# Altium schematic sheet Sheet1.SchDoc
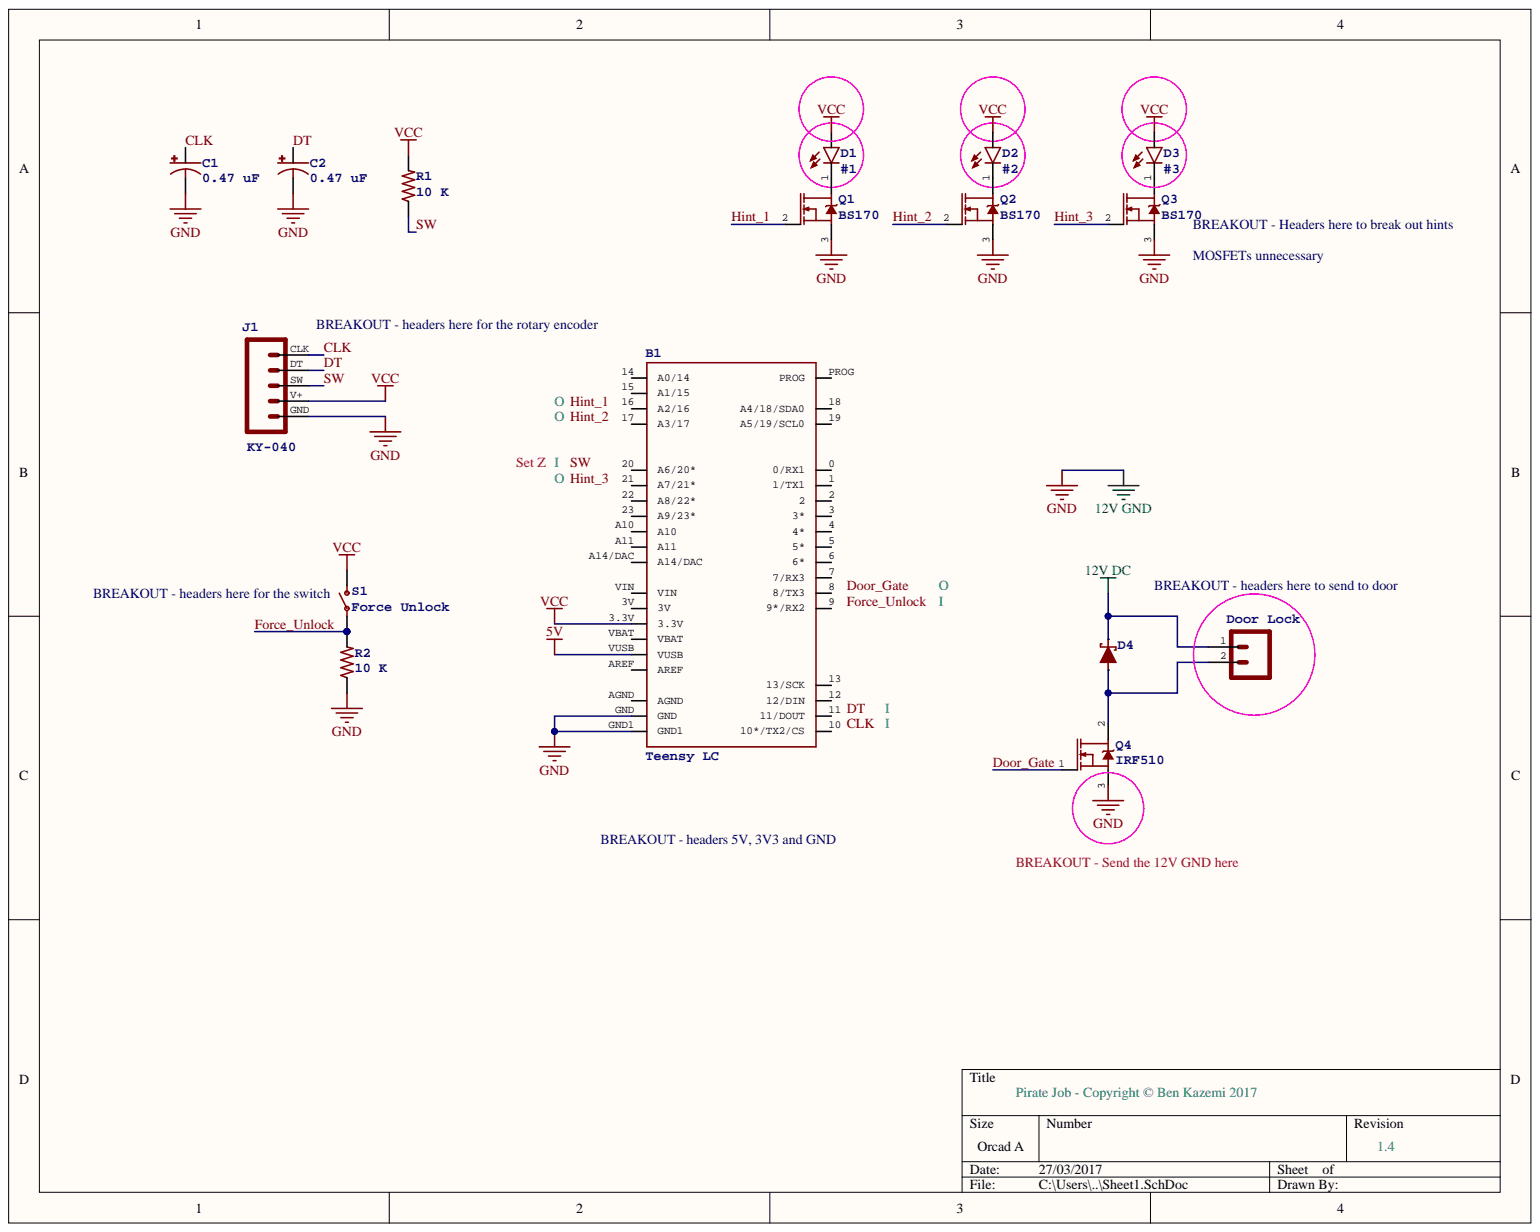
[diagram p0: Sheet1.SchDoc]

## Parts
B1  Teensy LC  [TEENSY-3.1]
C1  0.47 uF  [PANASONIC_D]
C2  0.47 uF  [PANASONIC_D]
D1  #1  [LED_5MM]
D2  #2  [LED_5MM]
D3  #3  [LED_5MM]
D4  (no value)  [SOD-323]
Door_Lock  (no value)  [1X02_LOCK]
J1  KY-040  [1X05]
Q1  BS170  [TO-92]
Q2  BS170  [TO-92]
Q3  BS170  [TO-92]
Q4  IRF510  [TO220V]
R1  10 K  [AXIAL-0.3]
R2  10 K  [AXIAL-0.3]
S1  Force Unlock  [SWITCH-SPST-VERTICAL]

## Nets
5V: B1.VUSB
12V DC: D4.C Door_Lock.1
12V GND: B1.GND B1.GND1 C1.- C2.- J1.GND Q1.3 Q2.3 Q3.3 Q4.3 R2.P$1
VCC: B1.3.3V D1.A D2.A D3.A J1.V+ R1.P$2 S1.1
CLK: C1.+ J1.CLK
DOOR_GATE: Q4.1
DT: C2.+ J1.DT
FORCE_UNLOCK: R2.P$2 S1.2
HINT_1: Q1.2
HINT_2: Q2.2
HINT_3: Q3.2
SW: J1.SW R1.P$1
NetD1_K: D1.K Q1.1
NetD2_K: D2.K Q2.1
NetD3_K: D3.K Q3.1
NetD4_A: D4.A Door_Lock.2 Q4.2

Not connected: B1 0(0/RX1) 1(1/TX1) 2 3(3*) 3V 4(4*) 5(5*) 6(6*) 7(7/RX3) 8(8/TX3) 9(9*/RX2) 10(10*/TX2/CS) 11(11/DOUT) 12(12/DIN) 13(13/SCK) 14(A0/14) 15(A1/15) 16(A2/16) 17(A3/17) 18(A4/18/SDA0) 19(A5/19/SCL0) 20(A6/20*) 21(A7/21*) 22(A8/22*) 23(A9/23*) A10 A11 A14/DAC AGND AREF PROG VBAT VIN

## Library data of the parts
B1 = TEENSY-3.1SILK: SF_ID: DEV-12646; Component Kind: Standard; Library Name: SparkFun-Boards.IntLib
C1 C2 = 47UF-POLAR-PANASONIC_D-50V-20%: Component Kind: Standard; Library Name: SparkFun-Capacitors.IntLib
D1-D3 = LED5MM: Component Kind: Standard; Library Name: SparkFun-LED.IntLib
D4 = DIODE-SCHOTTKY-BAT20J: Schottky diode    A Schottky diode is a semiconductor diode which has a low forward voltage drop and a very fast switching action.; PROD_ID: DIO-11623; Component Kind: Standard; Library Name: SparkFun-DiscreteSemi.IntLib
Door_Lock = CONN_02LOCK: Component Kind: Standard; Library Name: SparkFun-Connectors.IntLib
J1 = CONN_05: Rotary Encoder; Component Kind: Standard; Library Name: SparkFun-Connectors.IntLib
Q1-Q4 = MOSFET-NCH-FQP30N06L: PROD_ID: TRANS-10060; Component Kind: Standard; Library Name: SparkFun-DiscreteSemi.IntLib
R1 R2 = 10KOHM-HORIZ-1/4W-5%: PROD_ID: RES-09435; Component Kind: Standard; Library Name: SparkFun-Resistors.IntLib
S1 = SWITCH-SPST-2TV: Component Kind: Standard; Library Name: SparkFun-Retired.IntLib
